# Altium schematic sheet TopSheet.SchDoc
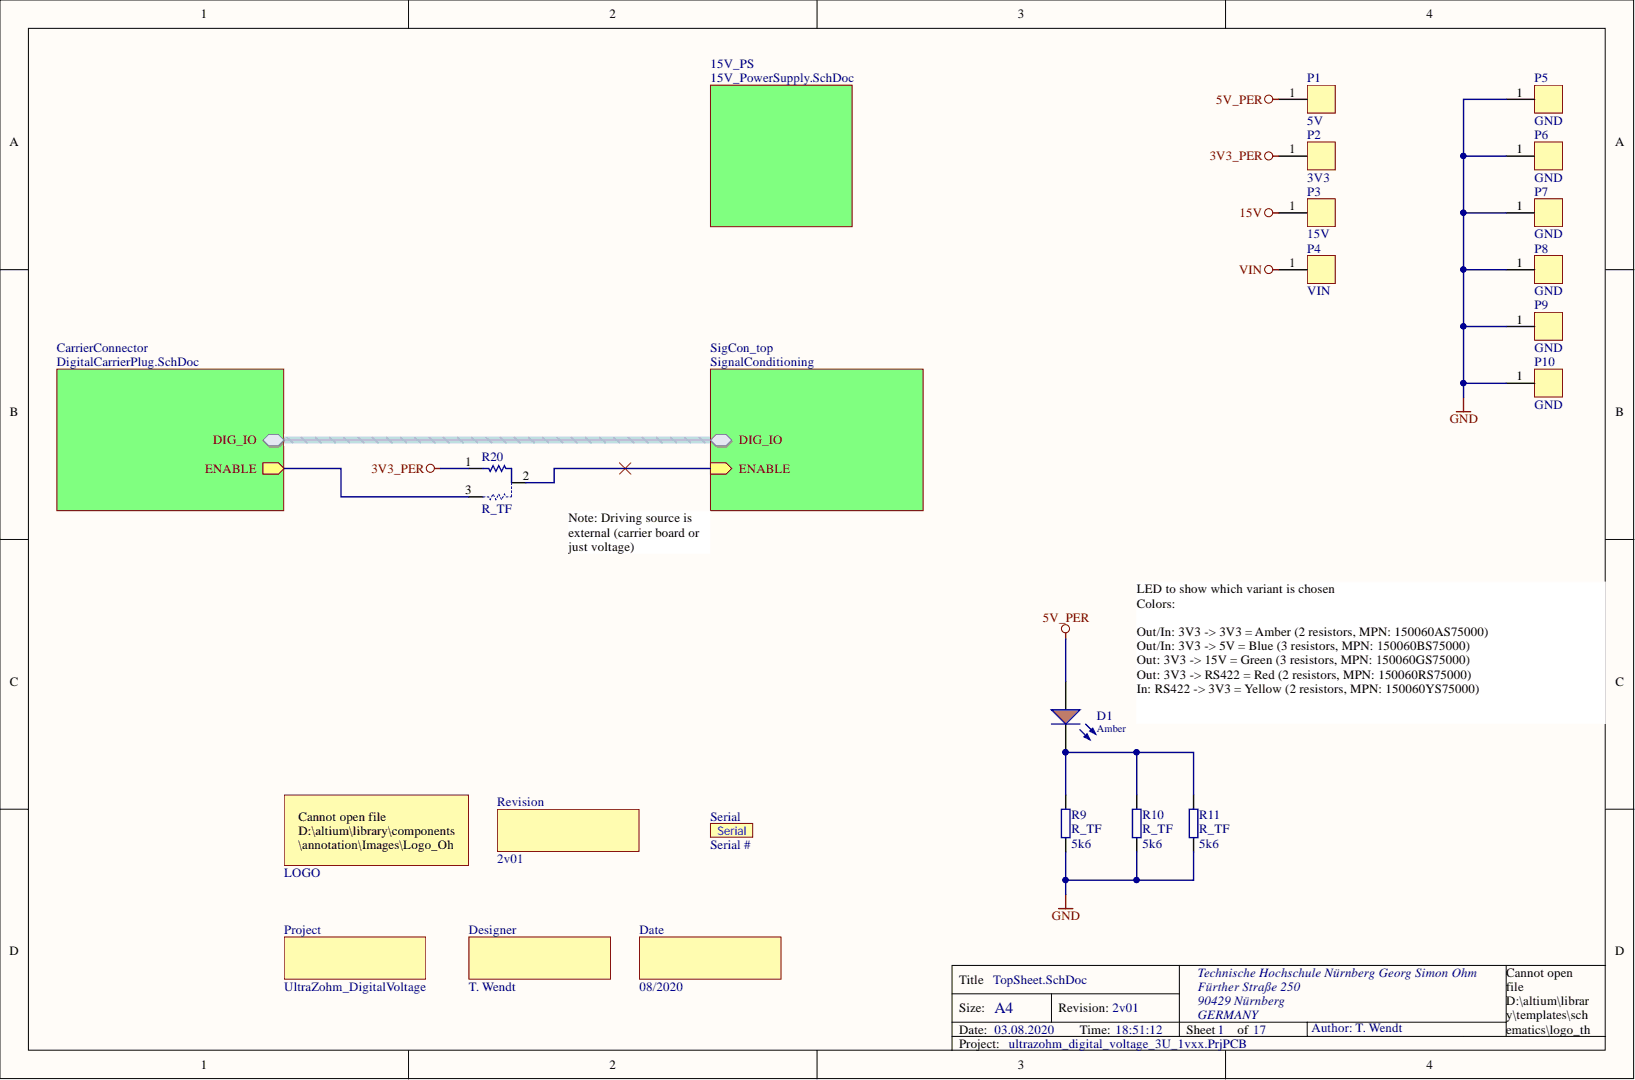
[diagram p0: TopSheet.SchDoc]

## Parts
D1  Emitting Color: Amber  [WL-SMCW_0603]  (hidden comment: LED_Amber)
D1  LED_Blue  [WL-SMCW_0603]  (value not drawn)
D1  LED_Green  [WL-SMCW_0603]  (value not drawn)
D1  LED_red  [WL-SMCW_0603]  (value not drawn)
D1  LED_Yellow  [WL-SMCW_0603]  (value not drawn)
Date  08/2020  [Comment]  (no BOM)
Designer  T. Wendt  [Designator:comment]  (no BOM)
LOGO  Logo_THN_TUM_USACH  [Logo_Partners_1]  (value not drawn)  (no BOM)
P1  5V  [PinHeader1]  (no BOM)
P2  3V3  [PinHeader1]  (no BOM)
P3  15V  [PinHeader1]  (no BOM)
P4  VIN  [PinHeader1]  (no BOM)
P5  GND  [PinHeader1]  (no BOM)
P6  GND  [PinHeader1]  (no BOM)
P7  GND  [PinHeader1]  (no BOM)
P8  GND  [PinHeader1]  (no BOM)
P9  GND  [PinHeader1]  (no BOM)
P10  GND  [PinHeader1]  (no BOM)
Project  UltraZohm_DigitalVoltage  [Designator:comment]  (no BOM)
R9  R_TF  value 5k6  [RESC_0603]
R10  R_TF  value 5k6  [RESC_0603]
R11  R_TF  value 5k6  [RESC_0603]
R20  R_TF  [RESC_0603_CONFIG]
Revision  2v01  [Designator:comment]  (no BOM)
Serial  Serial #  [SERIAL]  (no BOM)

## Nets
3V3_PER: P2.1 R20.1
5V_PER: D1.2 P1.1
15V: P3.1
GND: P5.1 P6.1 P7.1 P8.1 P9.1 P10.1 R9.2 R10.2 R11.2
VIN: P4.1
NetD1_1: D1.1 R9.1 R10.1 R11.1
NetR20_2: R20.2
NetR20_3: R20.3
DIG_IO.DIG_IO_01:
DIG_IO.DIG_IO_02:
DIG_IO.DIG_IO_03:
DIG_IO.DIG_IO_04:
DIG_IO.DIG_IO_05:
DIG_IO.DIG_IO_06:
DIG_IO.DIG_IO_07:
DIG_IO.DIG_IO_08:
DIG_IO.DIG_IO_09:
DIG_IO.DIG_IO_10:
DIG_IO.DIG_IO_11:
DIG_IO.DIG_IO_12:
DIG_IO.DIG_IO_13:
DIG_IO.DIG_IO_14:
DIG_IO.DIG_IO_15:
DIG_IO.DIG_IO_16:
DIG_IO.DIG_IO_17:
DIG_IO.DIG_IO_18:
DIG_IO.DIG_IO_19:
DIG_IO.DIG_IO_20:
DIG_IO.DIG_IO_21:
DIG_IO.DIG_IO_22:
DIG_IO.DIG_IO_23:
DIG_IO.DIG_IO_24:
DIG_IO.DIG_IO_25:
DIG_IO.DIG_IO_26:
DIG_IO.DIG_IO_27:
DIG_IO.DIG_IO_28:
DIG_IO.DIG_IO_29:
DIG_IO.DIG_IO_30:

## Library data of the parts
D1 = LED_Amber: WL-SMCW SMD Mono-color Chip LED Waterclear, size 0603, Red, 2 V, 140°; MPN: 150060AS75000; Dominant Wavelength: 605nm; Forward Voltage: 2 V; Luminous Intensity: 250 mcd; MF: Wuerth Elektronik; Peak Wavelength: 610nm; Value: 150060AS75000; Component Kind: Standard; ComponentLink1Description: Manufacturer; ComponentLink1URL: http://www.we-online.de; ComponentLink2Description: Datasheet; ComponentLink2URL: http://katalog.we-online.com/led/datasheet/150060AS75000.pdf; Library Name: optoelectronics.IntLib; Package: 0603; Published Date: Sep. 2017; Size: 0603; Supplier 1: Digi-Key; Supplier 2: Mouser; Supplier 3: Farnell; Supplier Part Number 1: 732-150060AS75000CT-ND; Supplier Part Number 2: 710-150060AS75000; Viewing Angle: 140°; Created: 2020-01-07_Thilo_Wendt
D1 = LED_Blue: WL-SMCW SMD Mono-color Chip LED Waterclear, size 0603, Blue, 3.2 V, 140°; MPN: 150060BS75000; Dominant Wavelength: 470 nm; Forward Voltage: 3.2 V; Luminous Intensity: 145 mcd; MF: Wuerth Elektronik; Peak Wavelength: 465 nm; Value: 150060BS75000; Component Kind: Standard; ComponentLink1Description: Manufacturer; ComponentLink1URL: http://www.we-online.de; ComponentLink2Description: Datasheet; ComponentLink2URL: http://katalog.we-online.com/led/datasheet/150060BS75000.pdf; Emitting Color: Blue; Library Name: optoelectronics.IntLib; Package: 0603; Published Date: Sep. 2017; Size: 0603; Supplier 1: Farnell; Supplier 2: Mouser; Supplier 3: Digi-Key; Supplier Part Number 1: 2322069; Supplier Part Number 2: 710-150060BS75000; Supplier Part Number 3: 732-4966-1-ND; Viewing Angle: 140°; Created: 2018_09_06_AGeiger
D1 = LED_Green: WL-SMCW SMD Mono-color Chip LED Waterclear, size 0603, Green, 3.2 V, 140°; MPN: 150060GS75000; Dominant Wavelength: 520 nm; Forward Voltage: 3.2 V; Luminous Intensity: 430 mcd; MF: Wuerth Elektronik; Peak Wavelength: 515 nm; Value: 150060GS75000; Component Kind: Standard; ComponentLink1Description: Manufacturer; ComponentLink1URL: http://www.we-online.de; ComponentLink2Description: Datasheet; ComponentLink2URL: http://katalog.we-online.com/led/datasheet/150060GS75000.pdf; Emitting Color: Green; Library Name: optoelectronics.IntLib; Package: 0603; Published Date: Sep. 2017; Size: 0603; Supplier 1: Farnell; Supplier 2: Mouser; Supplier 3: Digi-Key; Supplier Part Number 1: 2322070; Supplier Part Number 2: 710-150060GS75000; Supplier Part Number 3: 732-4971-1-ND; Viewing Angle: 140°; Created: 2018_09_06_AGeiger
D1 = LED_Red: WL-SMCW SMD Mono-color Chip LED Waterclear, size 0603, Red, 2 V, 140°; MPN: 150060RS75000; Dominant Wavelength: 625 nm; Forward Voltage: 2 V; Luminous Intensity: 250 mcd; MF: Wuerth Elektronik; Peak Wavelength: 630 nm; Value: 150060RS75000; Component Kind: Standard; ComponentLink1Description: Manufacturer; ComponentLink1URL: http://www.we-online.de; ComponentLink2Description: Datasheet; ComponentLink2URL: http://katalog.we-online.com/led/datasheet/150060RS75000.pdf; Emitting Color: Red; Library Name: optoelectronics.IntLib; Package: 0603; Published Date: Sep. 2017; Size: 0603; Supplier 1: Farnell; Supplier 2: Mouser; Supplier 3: Digi-Key; Supplier Part Number 1: 2322071; Supplier Part Number 2: 710-150060RS75000; Supplier Part Number 3: 732-4978-1-ND; Viewing Angle: 140°; Created: 2018_09_06_AGeiger
D1 = LED_Yellow: WL-SMCW SMD Mono-color Chip LED Waterclear, size 0603, Red, 2 V, 140°; MPN: 150060YS75000; Dominant Wavelength: 590; Emitting Color: Yellow; Forward Voltage: 2 V; Luminous Intensity: 250 mcd; MF: Wuerth Elektronik; Peak Wavelength: 595; Value: 150060YS75000; Component Kind: Standard; ComponentLink1Description: Manufacturer; ComponentLink1URL: http://www.we-online.de; ComponentLink2Description: Datasheet; ComponentLink2URL: http://katalog.we-online.com/led/datasheet/150060YS75000.pdf; Library Name: optoelectronics.IntLib; Package: 0603; Published Date: Sep. 2017; Size: 0603; Supplier 1: Mouser; Supplier 2: Farnell; Supplier 3: Digi-Key; Supplier Part Number 1: 710-150060YS75000; Supplier Part Number 2: 2322074; Supplier Part Number 3: 732-4981-1-ND; Viewing Angle: 140°; Created: 2020-01-07_Thilo_Wendt
Date = ProjectDate: Year and month of the design finish; Value: 08/2020; Created: 2020-01-08_Thilo_Wendt; Library Name: annotation.IntLib
Designer = ProjectAuthor: Value: T. Wendt; Created: 2020-01-08_Thilo_Wendt; Library Name: annotation.IntLib
LOGO = Logo_THN_TUM_USACH: Logo of THN TUM USACH; Created: 2020-01-08_Thilo_Wendt; Library Name: annotation.IntLib
P1-P10 = PinHeader1: Pin Header with 1 terminal; Library Name: connectors.IntLib; Created: 2020-01-08_Thilo_Wendt
  P1: Net: 5V
  P2: Net: 3V3
  P3: Net: 15V
  P4: Net: VIN
  P5-P10: Net: GND
Project = ProjectName: Value: UltraZohm_DigitalVoltage; Created: 2020-01-08_Thilo_Wendt; Library Name: annotation.IntLib
R9-R11 = R_0.1W_SMD: Standard thick film resistor; MPN: ERJU03F5601V; MaxVoltage: 100; MF: Panasonic; Parameter1: V; Parameter1Desc: Package Method; Parameter2: F; Parameter2Desc: Tolerance Symbol; Component Kind: Standard; ComponentLink1Description: Datasheet; ComponentLink1URL: https://www.mouser.de/datasheet/2/315/AOA0000C334-1314047.pdf; HelpURL: https://www.mouser.de/datasheet/2/315/AOA0000C334-1314047.pdf; Library Name: standard_rlc.IntLib; Package: RESC_0603; Supplier 1: Mouser; Supplier Part Number 1: 667-ERJ-U03F5601V; Created: 2019-12-08_Thilo_Wendt
R20 = R_0.1W_config: Solder resistor/jumper between pads 1 and 2 for standard function. Solder resistor between pads 2 and 3 for alternative function; MPN: ERJU030R00V; MaxVoltage: 100; MF: Panasonic; Parameter1: V; Parameter1Desc: Package Method; Parameter2: F; Parameter2Desc: Tolerance Symbol; Value: 0; Component Kind: Standard; ComponentLink1Description: Datasheet; ComponentLink1URL: https://www.mouser.de/datasheet/2/315/AOA0000C334-1314047.pdf; HelpURL: https://www.mouser.de/datasheet/2/315/AOA0000C334-1314047.pdf; Library Name: standard_rlc.IntLib; Package: RESC_0603_CONFIG; Supplier 1: Mouser; Supplier Part Number 1: 667-ERJ-U030R00V; Created: 2018_09_04_AGeiger
Revision = ProjectRevision: Value: 2v01; Created: 2020-01-08_Thilo_Wendt; Library Name: annotation.IntLib
Serial = SerialNumber: Empty serial number of the PCB. Can be used for manually writing the serial number; Library Name: annotation.IntLib
